# Altium schematic sheet CurrentSourceMB-Rev2b.SchDoc(CurrentSourceMB-Rev2b)
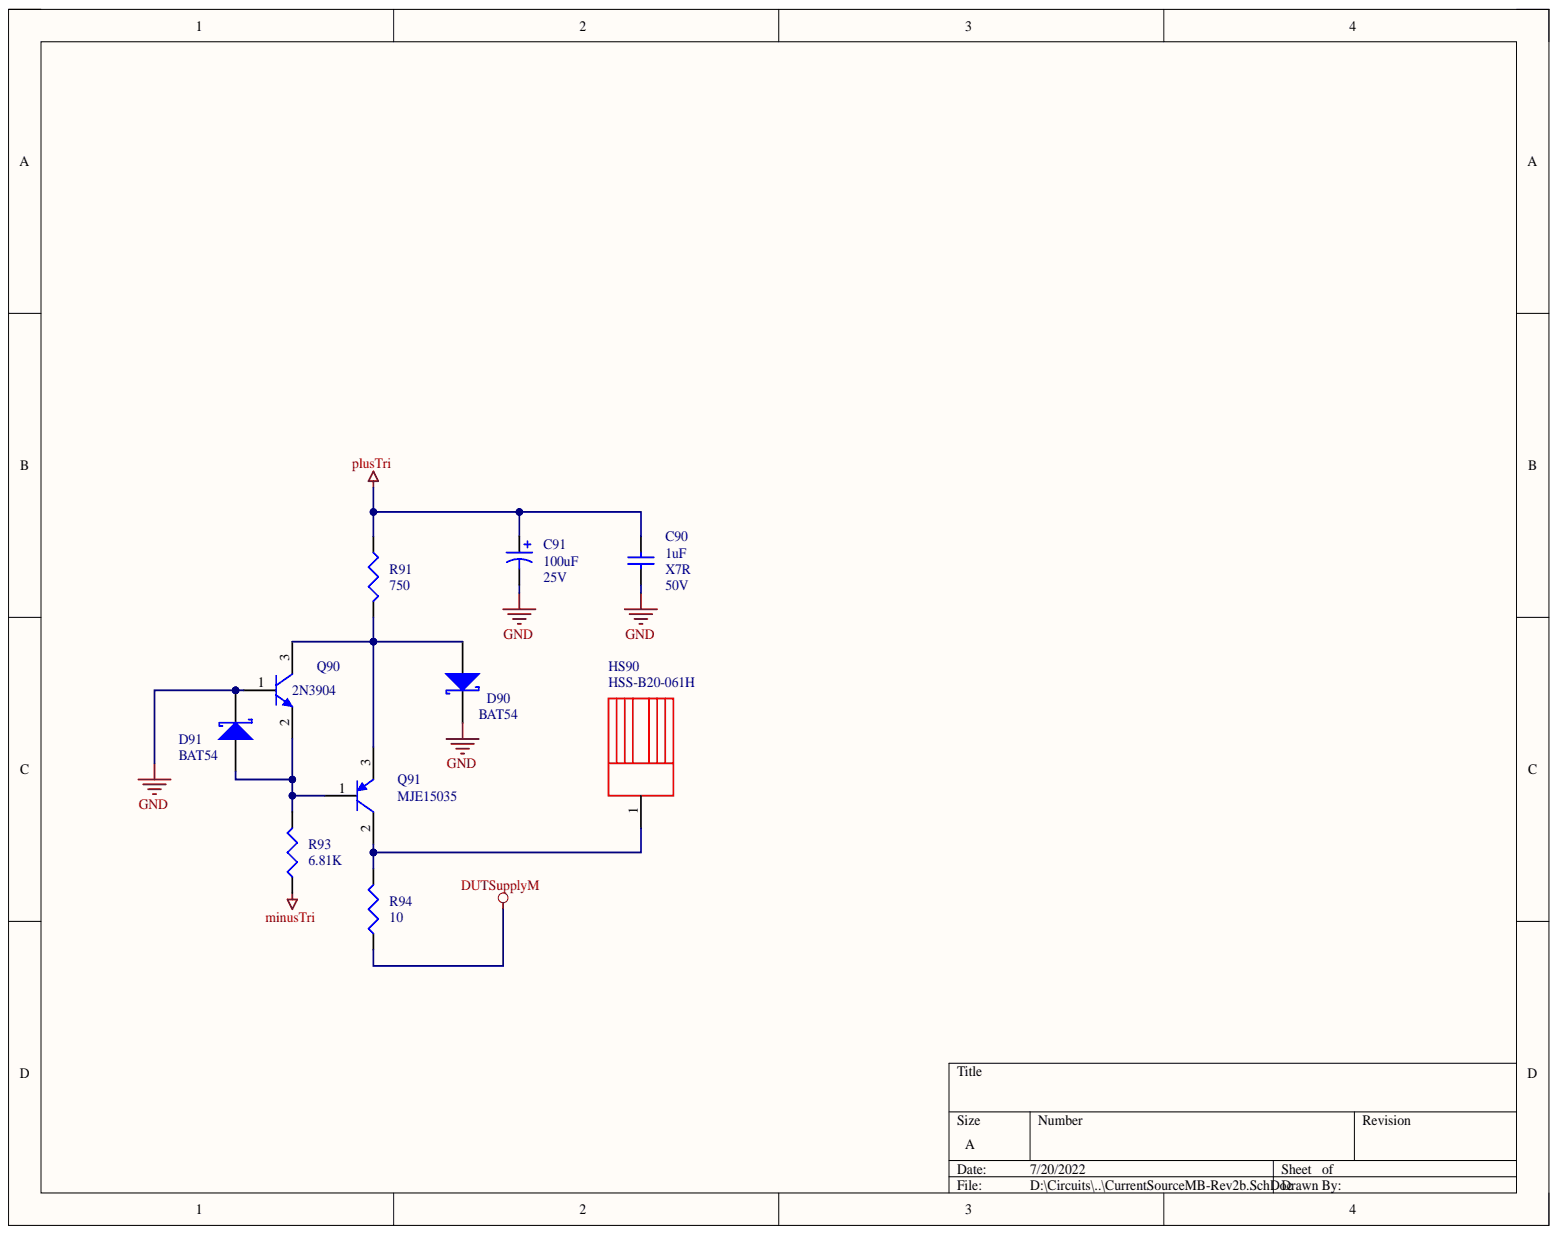
[diagram p0: CurrentSourceMB-Rev2b.SchDoc]

## Parts
C90  1uF  Dielectric: X7R  Voltage: 50V  [CAPC2012M]
C91  100uF  Voltage: 25V  [CAPPR2-5X11]
D90  BAT54  [SOD123]
D91  BAT54  [SOD123]
HS90  HSS-B20-061H  [262-75ABE-01]
Q90  2N3904  [SOT23-3M]
Q91  value MJE15035  Series: MJE15035  [TO220AB-123]  (hidden comment: MJE58731)
R91  750  [RESC3216M]
R93  6.81K  [RESC2012M]
R94  10  [RESC3216M]

## Nets
DUTSupplyM: R94.2
GND: C90.2 C91.2 D90.2 D91.2 Q90.1
minusTri: R93.2
plusTri: C90.1 C91.1 R91.1
NetD90_1: D90.1 Q90.3 Q91.3 R91.2
NetD91_1: D91.1 Q90.2 Q91.1 R93.1
NetHS90_1: HS90.1 Q91.2 R94.1

## Library data of the parts
C90 = Cap: CAP CER 1UF 50V X7R 0805; Manufacturer: Samsung; Manufacturer Part Number: CL21B105KBFNNNE; Dielectric Material: Ceramic; Tolerance: 10%; Capacitance: 1uF; Case Code (Imperial): 0805; Case Code (Metric): 2012; Case/Package: 0805; Component Kind: Standard; Construction: Flat; Depth: 1.25mm; Height: 1.25mm; Length: 2mm; Library Name: Miscellaneous Devices.IntLib; Max Operating Temperature: 125°C; Min Operating Temperature: -55°C; Mount: Surface Mount; Number of Pins: 2; Package Quantity: 1; Packaging: Tape and Reel; Radiation Hardening: No; REACH SVHC: No SVHC; RoHS Compliant: Yes; Series: CL; Signal Integrity: Cap; Simulation: CAP; Supplier: Digi-Key; Supplier Part Number: 1276-1029-6-ND; Termination: SMD/SMT; Thickness: 0.053inch; Value: 1uF; Voltage Rating: 50V; Voltage Rating (DC): 50V; Width: 0.049inch
C91 = Cap Pol1: Aluminum Electrolytic Capacitors - Leaded 100uF ±20% 25V Radial, 5x11mm RoHS; Manufacturer: Rubycon; Manufacturer Part Number: 25YXJ100M5X11; Impedance: 400mR; Lead Diameter: 0.5mm; Leakage Current: 3uA; Life (Hours): 5000Hour; Polarity: Polar; Ripple Current: 250mA; Capacitance: 100uF; Case/Package: Radial; Category: Aluminum Electrolytic Capacitors; Component Kind: Standard; ComponentLink1Description: https://datasheet.ciiva.com/11777/0900766b810307a1-11777001.pdf?src-supplier=RSComponents; ComponentLink1URL: https://datasheet.ciiva.com/11777/0900766b810307a1-11777001.pdf?src-supplier=RSComponents; ComponentLink2Description: https://datasheet.ciiva.com/41806/e-yxj-41806041.pdf?src-supplier=Digi-Key; ComponentLink2URL: https://datasheet.ciiva.com/41806/e-yxj-41806041.pdf?src-supplier=Digi-Key; ComponentLink3Description: https://datasheet.ciiva.com/11690/e-yxj-11690904.pdf?src-supplier=Avnet; ComponentLink3URL: https://datasheet.ciiva.com/11690/e-yxj-11690904.pdf?src-supplier=Avnet; Construction: RADIAL; Diameter: 5mm; Height: 11mm; Height - Seated (Max): 0.492inch; Lead Pitch: 2mm; Library Name: Miscellaneous Devices.IntLib; Max Operating Temperature: 105°C; Min Operating Temperature: -40°C; Mount: Through Hole; Package Quantity: 3000; Packaging: Bulk; Pricing 1: 1=0.25000, 10=0.17200, 50=0.10360, 100=0.08740, 500=0.05980, 1000=0.05290, 2500=0.05060, 5000=0.04600 (USD); Radiation Hardening: No; RoHS: Yes; RoHS Compliant: Yes; Series: YXJ; Signal Integrity: Cap Pol; Simulation: CAP; Stock 1: 7789; Supplier: Digi-Key; Supplier Part Number: 1189-2237-ND; Termination: Radial; Tolerance: 20%; Value: 100uF; Voltage Rating: 25V
D90 D91 = Diode-Schottkey-12: DIODE SCHOTTKY 30V 200mA SOD-123; Manufacturer: ON Semiconductor; Manufacturer Part Number: SBAT54T1G; Element Configuration: Single; Forward Voltage: 520mV; Max Forward Surge Current (Ifsm): 600mA; Max Power Dissipation: 400mW; Max Reverse Current: 500nA; Reverse Recovery Time: 5ns; Average Rectified Current: 200mA; Category: Schottky Diodes; Component Kind: Standard; ComponentLink1Description: http://www.farnell.com/datasheets/1840528.pdf?src-supplier=Farnell; ComponentLink1URL: http://www.farnell.com/datasheets/1840528.pdf?src-supplier=Farnell; ComponentLink2Description: http://www.mouser.com/ds/2/308/BAT54T1-D-108887.pdf?src-supplier=Mouser; ComponentLink2URL: http://www.mouser.com/ds/2/308/BAT54T1-D-108887.pdf?src-supplier=Mouser; ComponentLink3Description: http://www.onsemi.com/pub/Collateral/BAT54T1-D.PDF?src-supplier=Digi-Key; ComponentLink3URL: http://www.onsemi.com/pub/Collateral/BAT54T1-D.PDF?src-supplier=Digi-Key; ComponentLink4Description: http://www.onsemi.com/pub/Collateral/BAT54T1-D.PDF?src-supplier=Avnet; ComponentLink4URL: http://www.onsemi.com/pub/Collateral/BAT54T1-D.PDF?src-supplier=Avnet; Forward Current: 200mA; Lead Free: Lead Free; Library Name: MY_Diodes.SchLib; Max Operating Temperature: 125°C; Max Repetitive Reverse Voltage (Vrrm): 30V; Max Reverse Voltage (DC): 30V; Min Operating Temperature: -55°C; Mount: Surface Mount; Package Quantity: 3000; Packaging: Tape and Reel; Pricing 1: 1=0.48700, 5=0.48700, 10=0.36400, 100=0.22100 (USD); Reverse Voltage (DC): 30V; RoHS: Yes; RoHS Compliant: Yes; Stock 1: 1490; Supplier Part Number: 50AC7280; Value: BAT54
  D90: Supplier: Newark
  D91: Supplier: Digi-key
HS90 = HeatSink-1pin: TO-220 Vertical Heatsink 0.5X0.5X2.5 in; Manufacturer: CUI Devices; Component Kind: Standard; Library Name: MY_HeatSink.SchLib; Manufacturer Part Number: HSS-B20-061H; Supplier: Digi-Key; Supplier Part Number: 102-4167-ND; Value: HSS-B20-061H
Q90 = 2N3904: TRANS NPN 40V 0.2A SOT23; Manufacturer: ON Semiconductor; Manufacturer Part Number: MMBT3904LT1G; Current - Collector (Ic) (Max): 200mA; DC Current Gain (hFE) (Min) @ Ic, Vce: 100 @ 10mA, 1V; Frequency - Transition: 300MHz; Power - Max: 300mW; Vce Saturation (Max) @ Ib, Ic: 300mV @ 5mA, 50mA; Category: Discrete Semiconductor Products; Code_JEDEC: TO-92A; Component Kind: Standard; ComponentLink1Description: Manufacturer Link; ComponentLink1URL: http://www.fairchildsemi.com/; ComponentLink2Description: Datasheet; ComponentLink2URL: http://www.fairchildsemi.com/ds/2N%2F2N3904.pdf; LatestRevisionDate: 15-Jan-2003; LatestRevisionNote: PCB Footprint 'BCY-W3/D4.7' replaced; Library Name: My_Miscellaneous Devices.SchLib; Mounting Type: Surface Mount; Operating Temperature: -55°C ~ 150°C (TJ); Package / Case: TO-236-3, SC-59, SOT-23-3; PackageVersion: Sep-1998; Packaging: Cut Tape (CT); Part Status: Active; RoHS: RoHS Compliant; Signal Integrity: 2N3904; Simulation: 2N3904; Supplier: Digi-Key; Supplier Device Package: SOT-23-3 (TO-236); Supplier Part Number: MMBT3904LT1GOSCT-ND; Transistor Type: NPN; Value: 2N3904; Voltage - Collector Emitter Breakdown (Max): 40V
Q91 = 2N3906: PNP Ic=-4A Vceo=-350V hfe=100 P=2W TO-220; Manufacturer: ON Semiconductor; Manufacturer Part Number: MJE15035G; Collector Base Voltage (VCBO): 350V; Collector Emitter Saturation Voltage: 500mV; Current Rating: -4A; Element Configuration: Single; Emitter Base Voltage (VEBO): 5V; Frequency: 30MHz; hFE Min: 100; Max Collector Current: 4A; Max Power Dissipation: 50W; Number of Elements: 1; Power Dissipation: 2W; Voltage Rating (DC): -350V; Case/Package: TO-220-3; Category: BJTs; Collector Emitter Breakdown Voltage: 350V; Collector Emitter Voltage (VCEO): 350V; Collector-emitter Voltage-Max: 500mV; Component Kind: Standard; ComponentLink1Description: https://datasheet.ciiva.com/15138/getdatasheetpartid-42383-15138740.pdf?src-supplier=Verical; ComponentLink1URL: https://datasheet.ciiva.com/15138/getdatasheetpartid-42383-15138740.pdf?src-supplier=Verical; ComponentLink2Description: https://datasheet.ciiva.com/30480/0900766b8126cc03-30480472.pdf?src-supplier=RSComponents; ComponentLink2URL: https://datasheet.ciiva.com/30480/0900766b8126cc03-30480472.pdf?src-supplier=RSComponents; ComponentLink3Description: https://datasheet.ciiva.com/6358/mje5730-d-6358985.pdf?src-supplier=Digi-Key; ComponentLink3URL: https://datasheet.ciiva.com/6358/mje5730-d-6358985.pdf?src-supplier=Digi-Key; ComponentLink4Description: https://datasheet.ciiva.com/11204/mje15034-d-11204009.pdf?src-supplier=Avnet; ComponentLink4URL: https://datasheet.ciiva.com/11204/mje15034-d-11204009.pdf?src-supplier=Avnet; ComponentLink5Description: https://datasheet.ciiva.com/6359/mje15034-d-6359357.pdf?src-supplier=Digi-Key; ComponentLink5URL: https://datasheet.ciiva.com/6359/mje15034-d-6359357.pdf?src-supplier=Digi-Key; Contact Plating: Tin,Matte; Gain Bandwidth Product: 30MHz; Height: 9.28mm; Lead Free: Lead Free; Length: 10.28mm; Library Name: Miscellaneous Devices.IntLib; Max Frequency: 30MHz; Max Operating Temperature: 150°C; Min Operating Temperature: -65°C; Number of Pins: 3; Package Quantity: 50; Packaging: Rail/Tube; Polarity: PNP; Pricing 1: 1=2.06000, 10=1.84900, 100=1.48590, 500=1.22078, 1000=1.01150, 2000=0.95425 (USD); Radiation Hardening: No; REACH SVHC: No SVHC; RoHS: Yes; RoHS Compliant: Yes; Signal Integrity: 2N3906; Simulation: 2N3906; Stock 1: 1597; Supplier: Digi-Key; Supplier Part Number: MJE15035GOS-ND; Transition Frequency: 30MHz; Weight: 0.01lb; Width: 4.82mm
R91 = Res3: RES 750 OHM 1% 1/4W 1206; Manufacturer: Stackpole Electronics; Manufacturer Part Number: RMCF1206FT750R; Max Power Dissipation: 250mW; Resistance: 750R; Temperature Coefficient: 100ppm/°C; Case Code (Imperial): 1206; Case Code (Metric): 3216; Case/Package: 1206; Component Kind: Standard; Composition: Thick Film; Contact Plating: Tin,Matte; Depth: 1.6mm; Features: Automotive AEC-Q200; Height: 0.028inch; Lead Free: Lead Free; Length: 0.126inch; Library Name: Miscellaneous Devices.IntLib; Max Operating Temperature: 155°C; Military Standard: Not; Min Operating Temperature: -55°C; Mount: Surface Mount; Number of Terminations: 2; Packaging: Tape and Reel; Power Rating: 250mW; Radiation Hardening: No; Signal Integrity: Res; Simulation: RESISTOR; Supplier: Digi-Key; Supplier Part Number: RMCF1206FT750RCT-ND; Tolerance: 1%; Value: 750; Width: 0.063inch
R93 = Res3: RES 6.81KK OHM 1% 1/4W 0805; Manufacturer: KOA Speer; Manufacturer Part Number: RK73H2ATTD6811F; Power Rating: 125mW; Resistance: 6.81kR; Temperature Coefficient: 100ppm/°C; Voltage Rating: 150V; Case Code (Imperial): 0805; Case Code (Metric): 2012; Case/Package: 0805; Component Kind: Standard; Construction: Rectangular; Depth: 1.25mm; Height: 0.5mm; Lead Free: Lead Free; Length: 2mm; Library Name: Miscellaneous Devices.IntLib; Max Operating Temperature: 155°C; Military Standard: Not; Min Operating Temperature: -55°C; Mount: Surface Mount; Number of Pins: 2; Package Quantity: 5000; Packaging: Tape and Reel; Resistance Tolerance: 1%; Resistor Type: Thick Film; RoHS Compliant: Yes; Series: RK73H; Signal Integrity: Res; Simulation: RESISTOR; Supplier: Digi-Key; Supplier Part Number: 2019-RK73H2ATTD6811FCT-ND; Technology: THICK FILM; Termination: SMD/SMT; Tolerance: 1%; Value: 6.81K; Voltage Rating (DC): 150V; Width: 1.25mm
R94 = Res3: RES 10 OHM 1% 1/4W 1206; Manufacturer: Stackpole Electronics; Manufacturer Part Number: RMCF1206FT10R0; Max Power Dissipation: 250mW; Resistance: 10R; Temperature Coefficient: 100ppm/°C; Working Voltage: 200V; Case Code (Imperial): 1206; Case Code (Metric): 3216; Case/Package: 1206; Component Kind: Standard; Construction: Rectangular; Contact Plating: Tin,Matte; Depth: 1.6mm; Features: Automotive AEC-Q200; Height: 0.028inch; Lead Free: Lead Free; Length: 3.2mm; Library Name: Miscellaneous Devices.IntLib; Max Operating Temperature: 155°C; Military Standard: Not; Min Operating Temperature: -55°C; Mount: Surface Mount; Number of Terminations: 2; Packaging: Tape and Reel; Power Rating: 250mW; Radiation Hardening: No; Resistor Type: Thick Film; RoHS Compliant: Yes; Series: RMCF; Signal Integrity: Res; Simulation: RESISTOR; Supplier: Digi-Key; Supplier Part Number: RMCF1206FT10R0DKR-ND; Tolerance: 1%; Value: 10; Width: 0.063inch
